# Altium schematic sheet Main.SchDoc(Main)
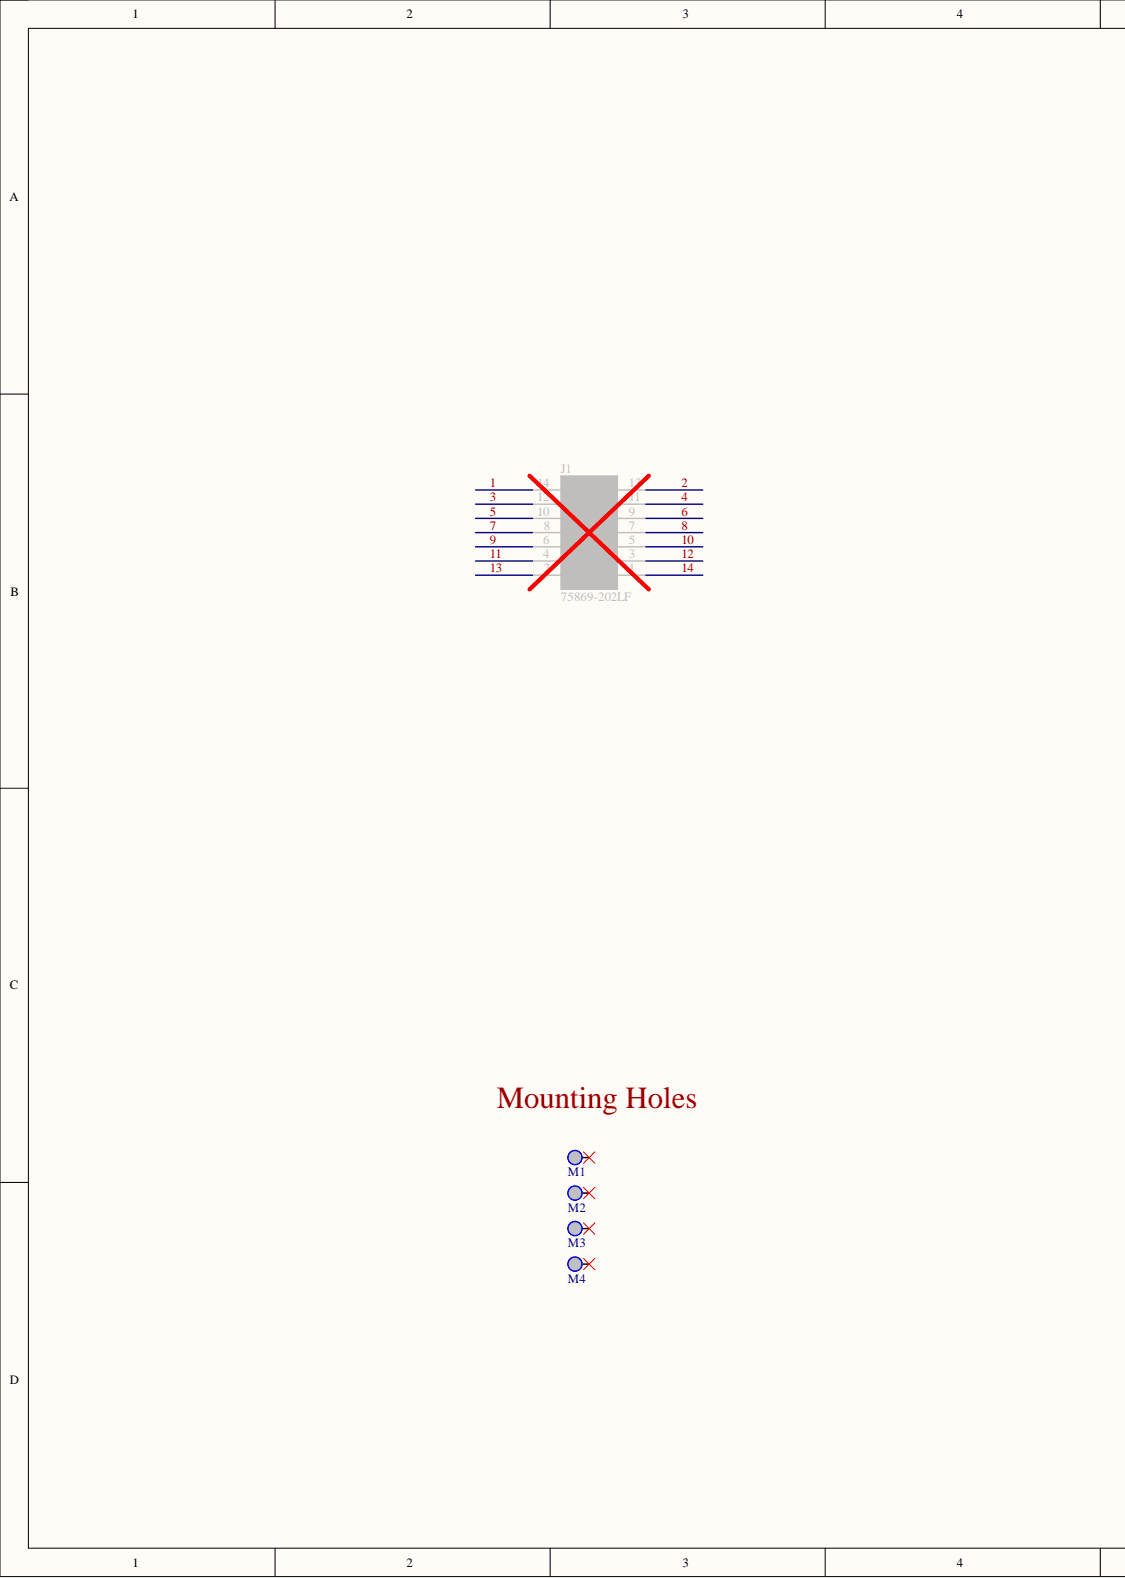
[diagram p0: Main.SchDoc, left]
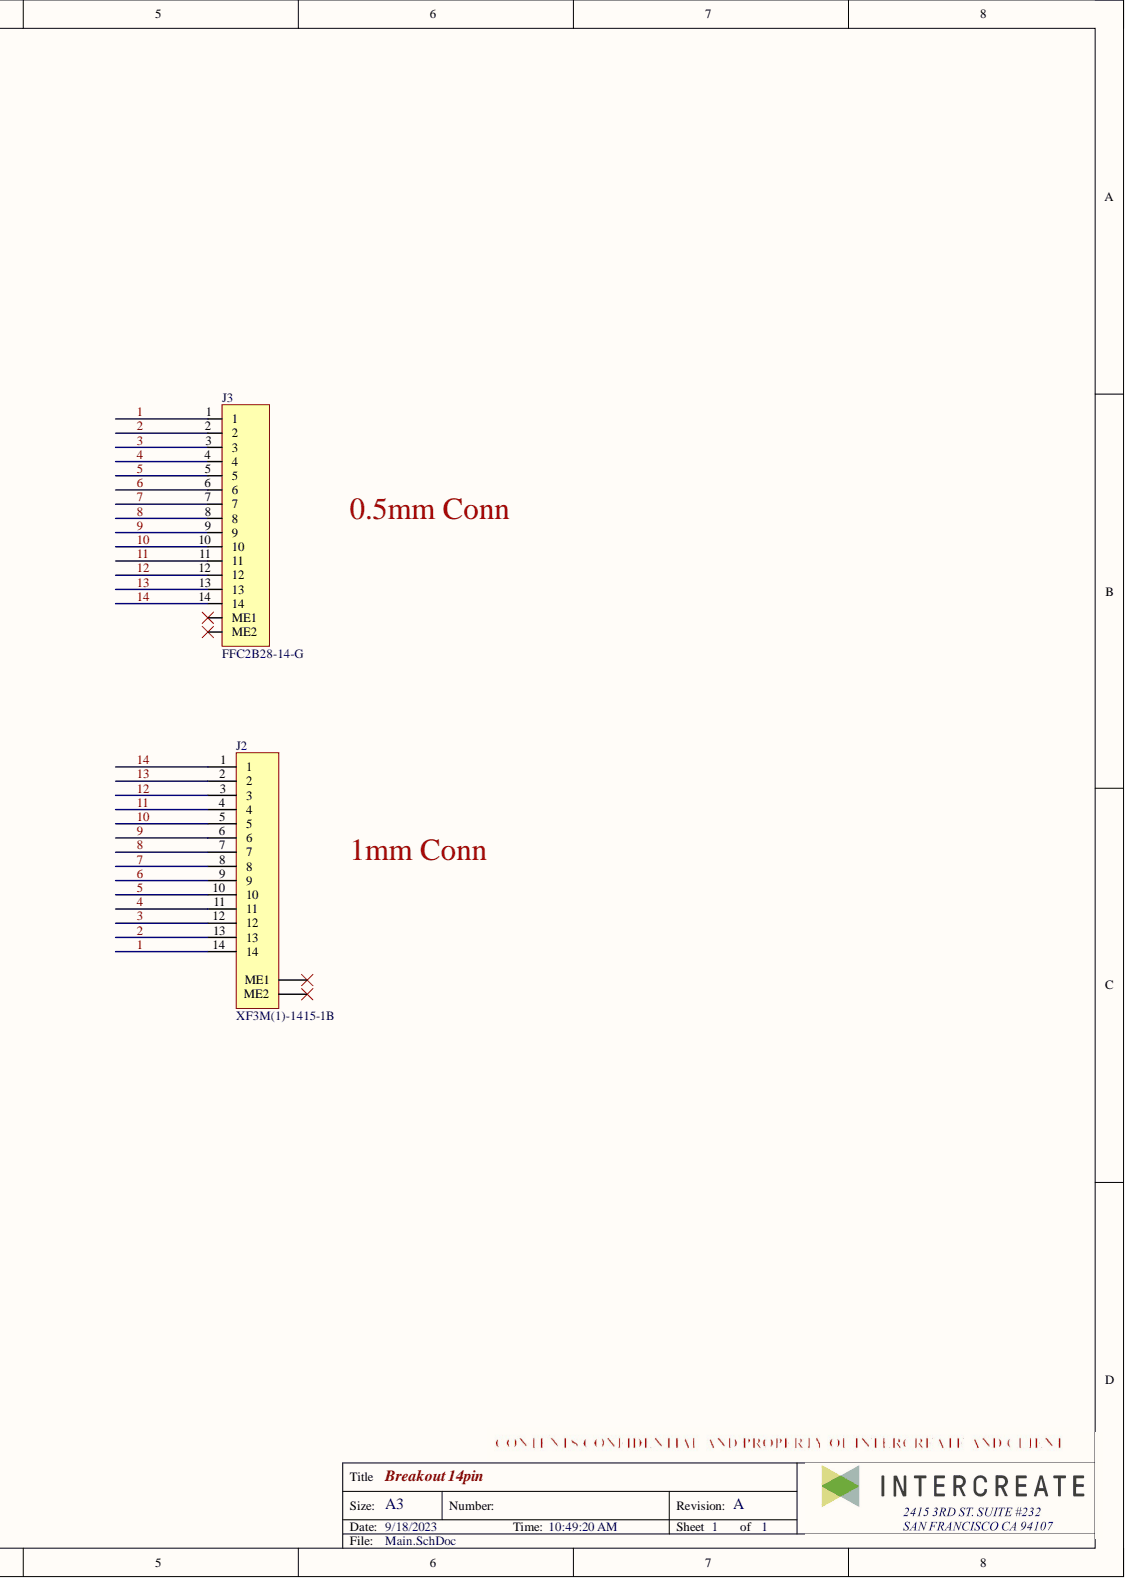
[diagram p1: Main.SchDoc, right]

## Parts
J1  75869-202LF  [HDR-V-2x7-KEYED]
J2  XF3M(1)-1415-1B  [CONN-FFC-H-14P-1MM]
J3  FFC2B28-14-G  [CONN-FFC-H-14P-0.5MM]
M1  MTG_HOLE  [MH_P_2.5MM]  (value not drawn)  (no BOM)
M2  MTG_HOLE  [MH_P_2.5MM]  (value not drawn)  (no BOM)
M3  MTG_HOLE  [MH_P_2.5MM]  (value not drawn)  (no BOM)
M4  MTG_HOLE  [MH_P_2.5MM]  (value not drawn)  (no BOM)

## Nets
1: J1.14 J2.14 J3.1
2: J1.13 J2.13 J3.2
3: J1.12 J2.12 J3.3
4: J1.11 J2.11 J3.4
5: J1.10 J2.10 J3.5
6: J1.9 J2.9 J3.6
7: J1.8 J2.8 J3.7
8: J1.7 J2.7 J3.8
9: J1.6 J2.6 J3.9
10: J1.5 J2.5 J3.10
11: J1.4 J2.4 J3.11
12: J1.3 J2.3 J3.12
13: J1.2 J2.2 J3.13
14: J1.1 J2.1 J3.14

Not connected: J2 ME1 ME2; J3 ME1 ME2; M1 1; M2 1; M3 1; M4 1

## Library data of the parts
J1 = HDR-V-2x7-M: Headers & Wire Housings 14P DR VERT SHRD HDR TIN; Manufacturer 1: Amphenol ICC / FCI; Connector Type: Male Pin; Contact Post Length: 3.05mm; Gender: Male; Max Voltage Rating (AC): 500V; Number of Contacts: 14; Pitch: 2.54mm; Row Spacing: 0.1inch; Category: Connectors; Color: Blue; Component Kind: Standard; ComponentLink1Description: https://datasheet.ciiva.com/723/75869-723760.pdf?src-supplier=Digi-Key; ComponentLink1URL: https://datasheet.ciiva.com/723/75869-723760.pdf?src-supplier=Digi-Key; Contact Material: Bronze; Contact Mating Length: 0.236inch; Contact Plating: Tin; Contact Type: Male Pin; Depth: 9.55mm; Housing Color: Blue; Housing Material: Thermoplastic; Lead Free: Lead Free; Length: 24.99mm; Manufacturer Part Number 1: 75869-202LF; Max Operating Temperature: 125°C; Min Operating Temperature: -65°C; Mount: Through Hole; Number of Positions: 14; Number of Rows: 2; Orientation: Straight; Package Quantity: 23; Packaging: Rail/Tube; Pricing 1: 1=1.00000, 10=0.87700, 100=0.75680, 500=0.61922, 1000=0.55041, 5000=0.48161, 10000=0.45753 (USD); RoHS: Yes; Series: Quickie™, Basics+; Stock 1: 665; Supplier 1: Digi-Key; Supplier Part Number 1: 609-75869-202LF-ND; Termination: Solder; Library Name: intercreate.IntLib
J2 = CONN-FFC-H-14P-1MM: 1.0-mm Pitch, Dual Contact ; For FPC/FFC, 14 Contacts, RoHS; Manufacturer 1: Omron; Actuator Color: Black; Actuator Material: Polymer,Liquid Crystal Polymer; Mating Cycles: 20; Number of Positions: 14; Voltage Rating: 50V; Category: FFC / FPC; Component Kind: Standard; ComponentLink1Description: https://omronfs.omron.com/en_US/ecb/products/pdf/en-xf3m.pdf?src-supplier=Digi-Key; ComponentLink1URL: https://omronfs.omron.com/en_US/ecb/products/pdf/en-xf3m.pdf?src-supplier=Digi-Key; ComponentLink2Description: https://datasheet.octopart.com/XF3M%281%29-1415-1B-Omron-datasheet-67143948.pdf?src-supplier=Master+Electronics; ComponentLink2URL: https://datasheet.octopart.com/XF3M%281%29-1415-1B-Omron-datasheet-67143948.pdf?src-supplier=Master+Electronics; Contact Material: Copper Alloy; Contact Plating: Gold; Flammability Rating: UL94 V-0; Housing Color: Natural; Housing Material: Polymer; Manufacturer Part Number 1: XF3M(1)-1415-1B; Max Operating Temperature: 85°C; Min Operating Temperature: -30°C; Mounting Technology: Surface Mount; Packaging: Cut Tape; Pins: 14; Pricing: 1=1.71, 10=1.491, 25=1.4272, 50=1.3954, 100=1.332, 250=1.20516, 500=1.11002 (USD); RoHS: No; Series: XF3M; Stock: 3056; Supplier: Digi-Key; Supplier Part Number: Z5875CT-ND; Termination: Solder; Library Name: intercreate.IntLib
J3 = CONN-FFC-H-14P-0.5MM: 14W, 0.5MM Ffc Con, R/a, Dual Cont,; Manufacturer 1: Global Connector Technology; Category: Connectors; Component Kind: Standard; Manufacturer Part Number 1: FFC2B28-14-G; Pricing 1: 1=0.28900, 10=0.28900, 25=0.28900, 50=0.26500, 100=0.26500, 250=0.26500, 500=0.24500 (USD); RoHS: No; Stock 1: 3095; Supplier 1: Newark; Supplier Part Number 1: 71AJ9616; Library Name: intercreate.IntLib
M1-M4 = MTG_HOLE: MTG HOLE PLATED VARIOUS SIZES; Library Name: intercreate.IntLib
